# Altium schematic sheet AnalogFrontEnd.SchDoc
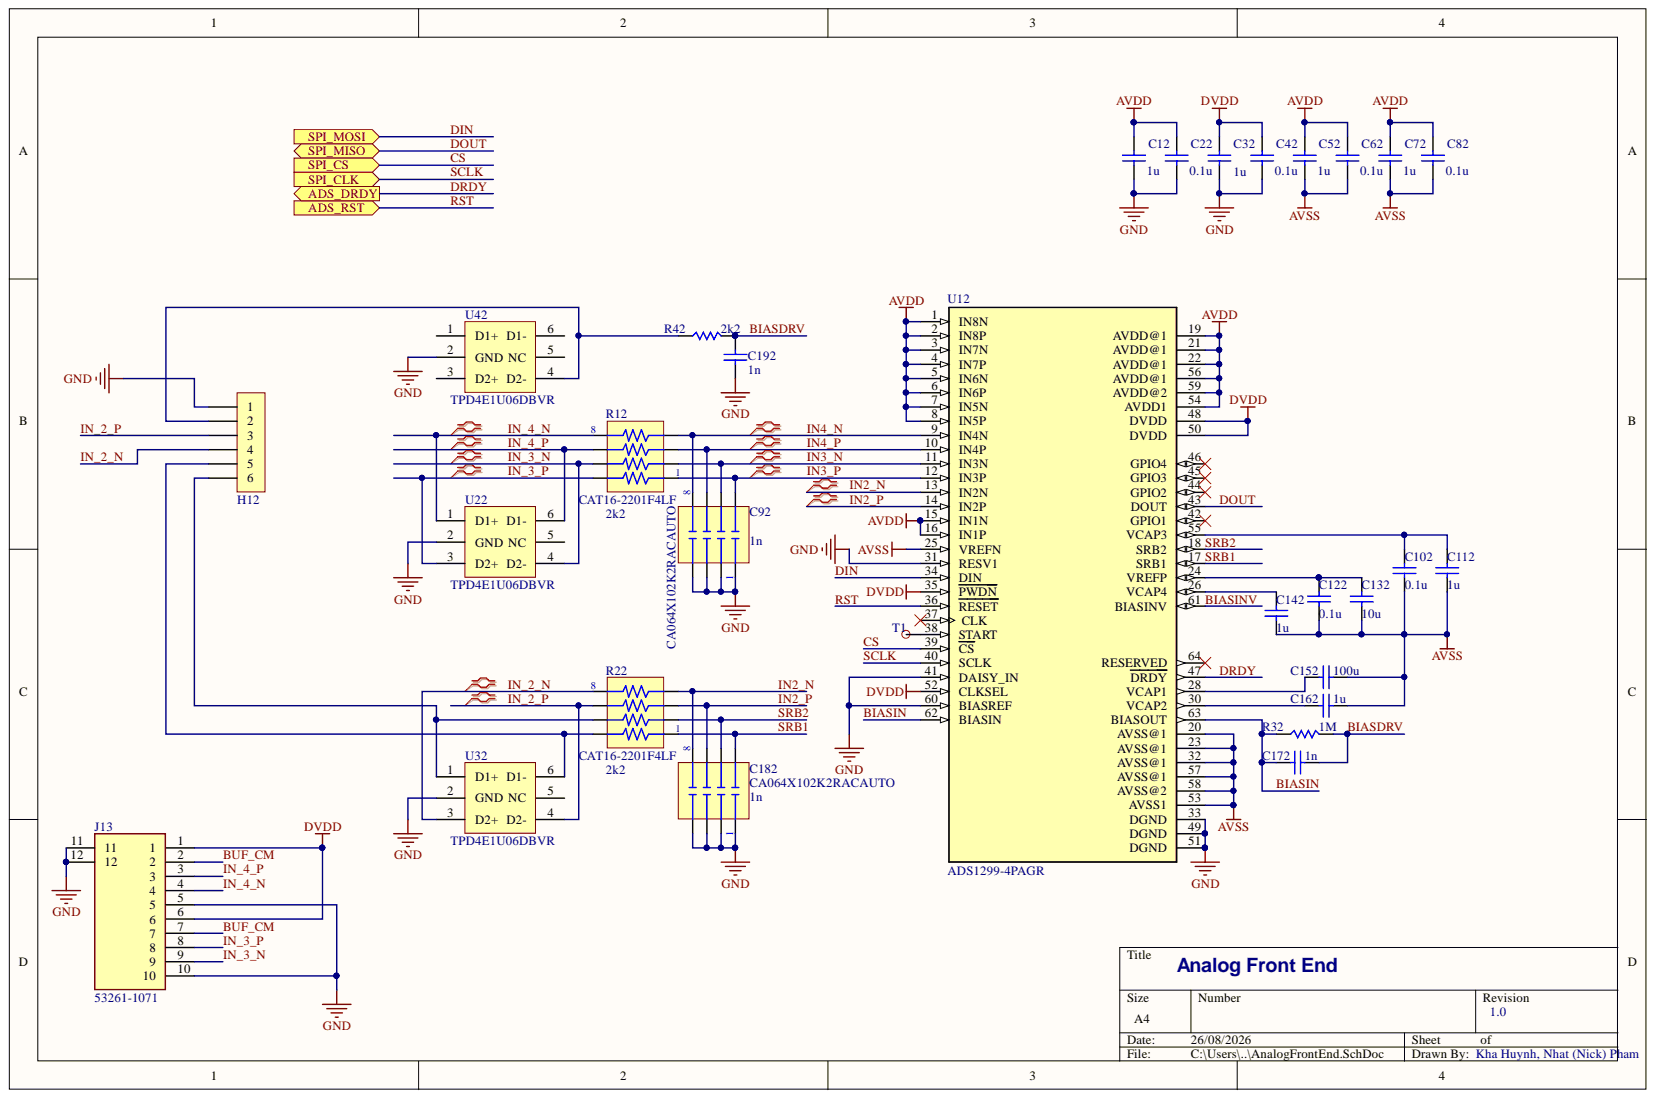
[diagram p0: AnalogFrontEnd.SchDoc]

## Parts
C12  1u  [0402 capacitor]
C22  0.1u  [0402 capacitor]
C32  1u  [0402 capacitor]
C42  0.1u  [0402 capacitor]
C52  1u  [0402 capacitor]
C62  0.1u  [0402 capacitor]
C72  1u  [0402 capacitor]
C82  0.1u  [0402 capacitor]
C92  CA064X102K2RACAUTO  value 1n  [CA4_1206]
C102  0.1u  [0402 capacitor]
C112  1u  [0402 capacitor]
C122  0.1u  [0402 capacitor]
C132  10u  [0402 capacitor]
C142  1u  [0402 capacitor]
C152  100u  [SM-1210]
C162  1u  [0402 capacitor]
C172  1n  [0402 capacitor]
C182  CA064X102K2RACAUTO  value 1n  [CA4_1206]
C192  1n  [0402 capacitor]
H12  HD6 PAD 2.54  [CardEdge 1x6 P2.54 L2.54]  (value not drawn)
J13  53261-1071  [532611071]
R12  CAT16-2201F4LF  value 2k2  [RA4_1206]
R22  CAT16-2201F4LF  value 2k2  [RA4_1206]
R32  1M  [0402 RESISTOR]
R42  2k2  [0402 RESISTOR]
T1  TestPoint  [TestPoint_top]  (value not drawn)
U12  ADS1299-4PAGR  [TQFP64-12x12-0.5]
U22  TPD4E1U06DBVR  [SOT23-6]
U32  TPD4E1U06DBVR  [SOT23-6]
U42  TPD4E1U06DBVR  [SOT23-6]

## Ports
ADS_DRDY, ADS_RST, SPI_CLK, SPI_CS, SPI_MISO, SPI_MOSI

## Nets
AVDD: C12.2 C22.2 C52.2 C62.2 C72.2 C82.2 U12.1(IN8N) U12.2(IN8P) U12.3(IN7N) U12.4(IN7P) U12.5(IN6N) U12.6(IN6P) U12.7(IN5N) U12.8(IN5P) U12.15(IN1N) U12.16(IN1P) U12.19(AVDD@1) U12.21(AVDD@1) U12.22(AVDD@1) U12.54(AVDD1) U12.56(AVDD@1) U12.59(AVDD@2)
AVSS: C52.1 C62.1 C72.1 C82.1 C102.1 C112.1 C122.1 C132.1 C142.1 C152.1 C162.1 U12.20(AVSS@1) U12.23(AVSS@1) U12.25(VREFN) U12.32(AVSS@1) U12.53(AVSS1) U12.57(AVSS@1) U12.58(AVSS@2)
DVDD: C32.2 C42.2 J13.1 J13.6 U12.35(PWDN) U12.48(DVDD) U12.50(DVDD) U12.52(CLKSEL)
GND: C12.1 C22.1 C32.1 C42.1 C92.1 C92.3 C92.5 C92.7 C182.1 C182.3 C182.5 C182.7 C192.2 H12.1 J13.5 J13.10 J13.11 J13.12 U12.31(RESV1) U12.33(DGND) U12.41(DAISY_IN) U12.49(DGND) U12.51(DGND) U12.60(BIASREF) U22.2(GND) U32.2(GND) U42.2(GND)
BIASDRV: C172.1 C192.1 R32.2 R42.2
BIASIN: C172.2 R32.1 U12.62(BIASIN) U12.63(BIASOUT)
BIASINV: U12.61(BIASINV)
BUF_CM: J13.2 J13.7
CS: U12.39(CS) ; port SPI_CS
DIN: U12.34(DIN) ; port SPI_MOSI
DOUT: U12.43(DOUT) ; port SPI_MISO
DRDY: U12.47(DRDY) ; port ADS_DRDY
IN2_N: C182.8 R22.7 U12.13(IN2N)
IN2_P: C182.6 R22.5 U12.14(IN2P)
IN3_N: C92.4 R12.3 U12.11(IN3N)
IN3_P: C92.2 R12.1 U12.12(IN3P)
IN4_N: C92.8 R12.7 U12.9(IN4N)
IN4_P: C92.6 R12.5 U12.10(IN4P)
IN_2_N: H12.4 R22.8 U32.3(D2+)
IN_2_P: H12.3 R22.6 U32.4(D2-)
IN_3_N: J13.9 R12.4 U22.4(D2-)
IN_3_P: J13.8 R12.2 U22.3(D2+)
IN_4_N: J13.4 R12.8 U22.1(D1+)
IN_4_P: J13.3 R12.6 U22.6(D1-)
RST: U12.36(RESET) ; port ADS_RST
SCLK: U12.40(SCLK) ; port SPI_CLK
SRB1: C182.2 R22.1 U12.17(SRB1)
SRB2: C182.4 R22.3 U12.18(SRB2)
NetC102_2: C102.2 C112.2 U12.55(VCAP3)
NetC122_2: C122.2 C132.2 U12.24(VREFP)
NetC142_2: C142.2 U12.26(VCAP4)
NetC152_2: C152.2 U12.28(VCAP1)
NetC162_2: C162.2 U12.30(VCAP2)
NetH12_2: H12.2 R42.1 U42.4(D2-)
NetH12_5: H12.5 R22.2 U32.6(D1-)
NetH12_6: H12.6 R22.4 U32.1(D1+)
NetT1_1: T1.1 U12.38(START)

Not connected: U12 37(CLK) 42(GPIO1) 44(GPIO2) 45(GPIO3) 46(GPIO4) 64(RESERVED); U22 5(NC); U32 5(NC); U42 1(D1+) 3(D2+) 5(NC) 6(D1-)

## Library data of the parts
C12 C32 C52 C72 C112 C142 C162 = C_Ceramic: Non-polarity ceramic capacitor; Manufacturer Part Number: GRM155R61A105KE15D; Component Kind: Standard; JLCPCB Part #: C76999; LatestRevisionDate: 17-Jul-2002; LatestRevisionNote: Re-released for DXP Platform.; PackageDescription: Radial Cap, Thru-Hole; 2 Leads; 0.3 in Pin Spacing; PackageReference: RAD-0.3; Published: 8-Jun-2000; Value: 1u; Library Name: Nhat_Lib.SchLib; Publisher: Altium Limited
C22 C42 C62 C82 C102 C122 = C_Ceramic: Non-polarity ceramic capacitor; Manufacturer Part Number: GCM155R71C104JA55D; Component Kind: Standard; JLCPCB Part #: C2181836; LatestRevisionDate: 17-Jul-2002; LatestRevisionNote: Re-released for DXP Platform.; PackageDescription: Radial Cap, Thru-Hole; 2 Leads; 0.3 in Pin Spacing; PackageReference: RAD-0.3; Published: 8-Jun-2000; Value: 0.1u; Library Name: Nhat_Lib.SchLib; Publisher: Altium Limited
C92 C182 = CA4: Cap array 4; Manufacturer Part Number: CA0612KRX7R9BB102; Component Kind: Standard; JLCPCB Part #: C541606; Library Name: Nhat_Lib.SchLib
C132 = C_Ceramic: Non-polarity ceramic capacitor; Manufacturer Part Number: GRM155R61A106ME44D; Component Kind: Standard; JLCPCB Part #: C77000; LatestRevisionDate: 17-Jul-2002; LatestRevisionNote: Re-released for DXP Platform.; PackageDescription: Radial Cap, Thru-Hole; 2 Leads; 0.3 in Pin Spacing; PackageReference: RAD-0.3; Published: 8-Jun-2000; Value: 10u; Library Name: Nhat_Lib.SchLib; Publisher: Altium Limited
C152 = C_Ceramic: Non-polarity ceramic capacitor; Manufacturer Part Number: MSASE32MAB5107MPNA01; Component Kind: Standard; JLCPCB Part #: C5372270; LatestRevisionDate: 17-Jul-2002; LatestRevisionNote: Re-released for DXP Platform.; PackageDescription: Radial Cap, Thru-Hole; 2 Leads; 0.3 in Pin Spacing; PackageReference: RAD-0.3; Published: 8-Jun-2000; Value: 100u; Library Name: Nhat_Lib.SchLib; Publisher: Altium Limited
C172 C192 = C_Ceramic: Non-polarity ceramic capacitor; Manufacturer Part Number: GRM1555C1H102JA01D; Component Kind: Standard; JLCPCB Part #: C76947; LatestRevisionDate: 17-Jul-2002; LatestRevisionNote: Re-released for DXP Platform.; PackageDescription: Radial Cap, Thru-Hole; 2 Leads; 0.3 in Pin Spacing; PackageReference: RAD-0.3; Published: 8-Jun-2000; Value: 1n; Library Name: Nhat_Lib.SchLib; Publisher: Altium Limited
J13 = 53261-1071: Connector; Manufacturer_Name: Molex; Component Kind: Standard; Datasheet Link: https://www.molex.com/webdocs/datasheets/pdf/en-us/0532611071_PCB_HEADERS.pdf; Height: 3mm; Manufacturer_Part_Number: 53261-1071; Mouser Part Number: 538-53261-1071; Mouser Price/Stock: https://www.mouser.co.uk/ProductDetail/Molex/53261-1071?qs=%252B72YyncTwW%252Bzs3RwZTiukQ%3D%3D; Library Name: KhaHuynhV12.SchLib
R12 R22 = RA4: Manufacturer Part Number: CAY16-2201F4LF; Component Kind: Standard; JLCPCB Part #: C1734175; Library Name: Nhat_Lib.SchLib
R32 = R: Resistor; Manufacturer Part Number: RC0402FR-071ML; Component Kind: Standard; JLCPCB Part #: C138033; LatestRevisionDate: 29-May-2009; LatestRevisionNote: IPC-7351 Footprint Added.; PackageDescription: Chip Resistor; PackageReference: J1-0603; Published: 8-Jun-2000; Value: 1M; Library Name: Nhat_Lib.SchLib; Publisher: Altium Limited
R42 = R: Resistor; Manufacturer Part Number: CRCW04022K20FKED; Component Kind: Standard; JLCPCB Part #: C82680; LatestRevisionDate: 29-May-2009; LatestRevisionNote: IPC-7351 Footprint Added.; PackageDescription: Chip Resistor; PackageReference: J1-0603; Published: 8-Jun-2000; Value: 2k2; Library Name: Nhat_Lib.SchLib; Publisher: Altium Limited
U12 = ADS1299-4PAG: Low-Noise, 4-Channel, 24-Bit, Analog-to-Digital Converter for EEG and  Biopotential Measurements; Component Kind: Standard; JLCPCB Part #: C2651574; Manufacturer Part Number: ADS1299-4PAGR; Library Name: Nhat_Lib.SchLib
U22 U32 U42 = TPD4E1U06: Quad-Channel, High-Speed ESD Protection Device; Component Kind: Standard; JLCPCB Part #: C124691; Manufacturer Part Number: TPD4E1U06DBVR; Library Name: Nhat_Lib.SchLib
H12 = HD6 PAD 2.54: Component Kind: Standard; Library Name: KhaHuynhV12.SchLib
T1 = TestPoint: Component Kind: Standard; Library Name: Nhat_Lib.SchLib
